# Altium schematic sheet AD8302相位检测模块.SchDoc(AD8302相位检测模块)
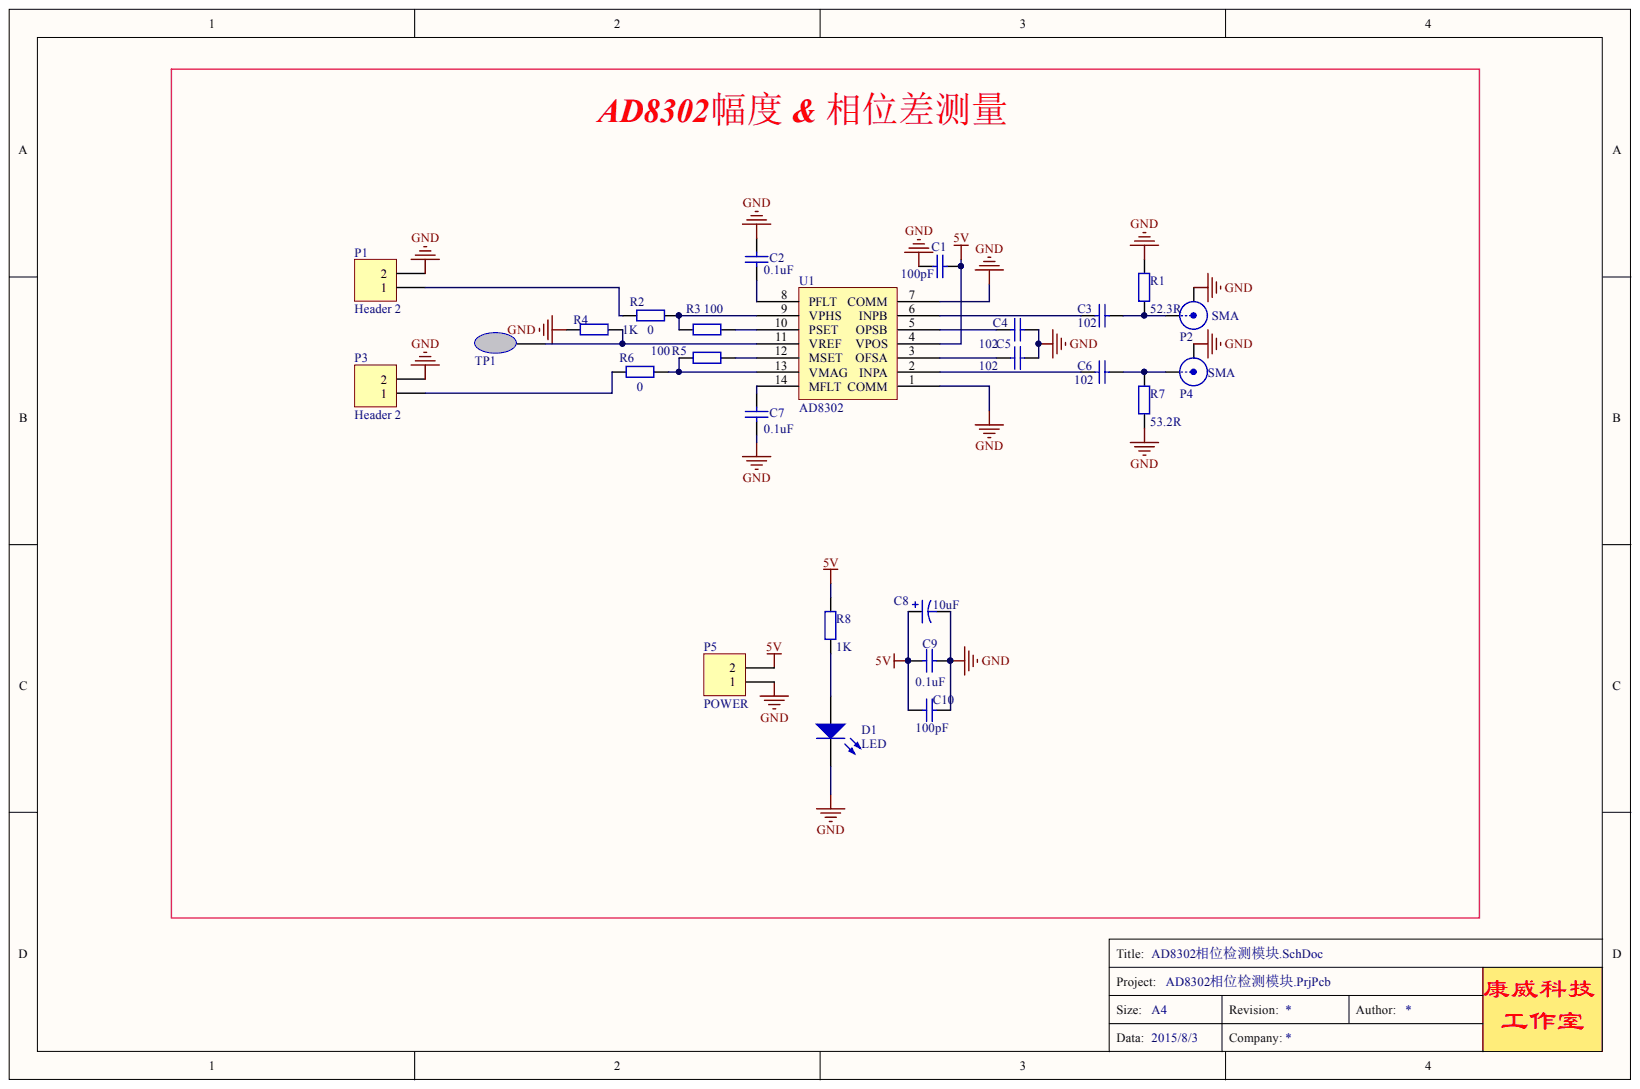
[diagram p0: AD8302相位检测模块.SchDoc]

## Parts
C1  value 100pF  [C0805]
C2  value 0.1uF  [C0805]
C3  value 102  [C0805]
C4  value 102  [C0805]
C5  value 102  [C0805]
C6  value 102  [C0805]
C7  value 0.1uF  [C0805]
C8  value 10uF  [C3528]
C9  value 0.1uF  [C0805]
C10  value 100pF  [C0805]
D1  LED  [0805-led]
P1  Header 2  [HDR1X2]
P2  SMA  [SM]
P3  Header 2  [HDR1X2]
P4  SMA  [SM]
P5  POWER  [XH2.54-2]
R1  value 52.3R  [R0805]
R2  value 0  [R0805]
R3  value 100  [R0805]
R4  value 1K  [R0805]
R5  value 100  [R0805]
R6  value 0  [R0805]
R7  value 53.2R  [R0805]
R8  value 1K  [R0805]
TP1  TEST  [TEST]  (value not drawn)
U1  AD8302  [TSSOP14]

## Nets
5V: C1.1 C8.1 C9.1 C10.1 P5.2 R8.1 U1.4(VPOS)
GND: C1.2 C2.2 C4.1 C5.1 C7.1 C8.2 C9.2 C10.2 D1.2 P1.2 P2.2 P3.2 P4.2 P5.1 R1.1 R4.1 R7.2 U1.1(COMM) U1.7(COMM)
NetC2_1: C2.1 U1.8(PFLT)
NetC3_1: C3.1 P2.1 R1.2
NetC3_2: C3.2 U1.6(INPB)
NetC4_2: C4.2 U1.5(OPSB)
NetC5_2: C5.2 U1.3(OFSA)
NetC6_1: C6.1 P4.1 R7.1
NetC6_2: C6.2 U1.2(INPA)
NetC7_2: C7.2 U1.14(MFLT)
NetD1_1: D1.1 R8.2
NetP1_1: P1.1 R2.1
NetP3_1: P3.1 R6.1
NetR2_2: R2.2 R3.1 U1.9(VPHS)
NetR3_2: R3.2 U1.10(PSET)
NetR4_2: R4.2 TP1.1 U1.11(VREF)
NetR5_1: R5.1 R6.2 U1.13(VMAG)
NetR5_2: R5.2 U1.12(MSET)
AD8302幅度 & 相位差测量:

## Library data of the parts
C1-C7 C9 C10 = Cap: Capacitor; Component Kind: Standard; LatestRevisionDate: 17-Jul-2002; LatestRevisionNote: Re-released for DXP Platform.; Library Name: Miscellaneous Devices.IntLib; PackageDescription: Radial Cap, Thru-Hole; 2 Leads; 0.3 in Pin Spacing; PackageReference: RAD-0.3; Published: 8-Jun-2000; Signal Integrity: Cap; Simulation: CAP; Publisher: Altium Limited
C8 = Cap Pol1: Polarized Capacitor (Radial); Component Kind: Standard; LatestRevisionDate: 17-Jul-2002; LatestRevisionNote: Re-released for DXP Platform.; Library Name: Miscellaneous Devices.IntLib; PackageDescription: Polarized Capacitor (Radial); 2 Leads; PackageReference: RB7.6-15; Published: 8-Jun-2000; Signal Integrity: Cap Pol; Simulation: CAP; Publisher: Altium Limited
P1 P3 P5 = Header 2: Header, 2-Pin; Component Kind: Standard; LatestRevisionDate: 17-Jul-2002; LatestRevisionNote: Re-released for DXP Platform.; Signal Integrity: Connector; Library Name: Xiaowei.SchLib; Publisher: Altium Limited
P2 P4 = BNC: BNC Elbow Connector; Component Kind: Standard; LatestRevisionDate: 17-Jul-2002; LatestRevisionNote: Re-released for DXP Platform.; Library Name: Miscellaneous Devices.SchLib; Signal Integrity: Connector; Publisher: Altium Limited
R1-R8 = Res2: Resistor; Component Kind: Standard; LatestRevisionDate: 17-Jul-2002; LatestRevisionNote: Re-released for DXP Platform.; Library Name: Miscellaneous Devices.IntLib; PackageDescription: Axial Device, Thru-Hole; 2 Leads; 0.4 in Pin Spacing; PackageReference: AXIAL-0.4; Published: 8-Jun-2000; Signal Integrity: Res; Simulation: RESISTOR; Publisher: Altium Limited
TP1 = 测试口: Component Kind: Standard; Library Name: Xiaowei.SchLib
D1 = LED: Component Kind: Standard; LatestRevisionDate: 08-Jul-2005; LatestRevisionNote: Mech Layer 1 removed.; PackageDescription: LED; 2 Leads; PackageReference: LED-0; Published: 8-Jun-2000; Library Name: Xiaowei.SchLib; Publisher: Altium Limited
U1 = AD8302: Component Kind: Standard; Library Name: Xiaowei.SchLib
